# Altium schematic sheet lv_signal_link.SchDoc(lv_signal_link)
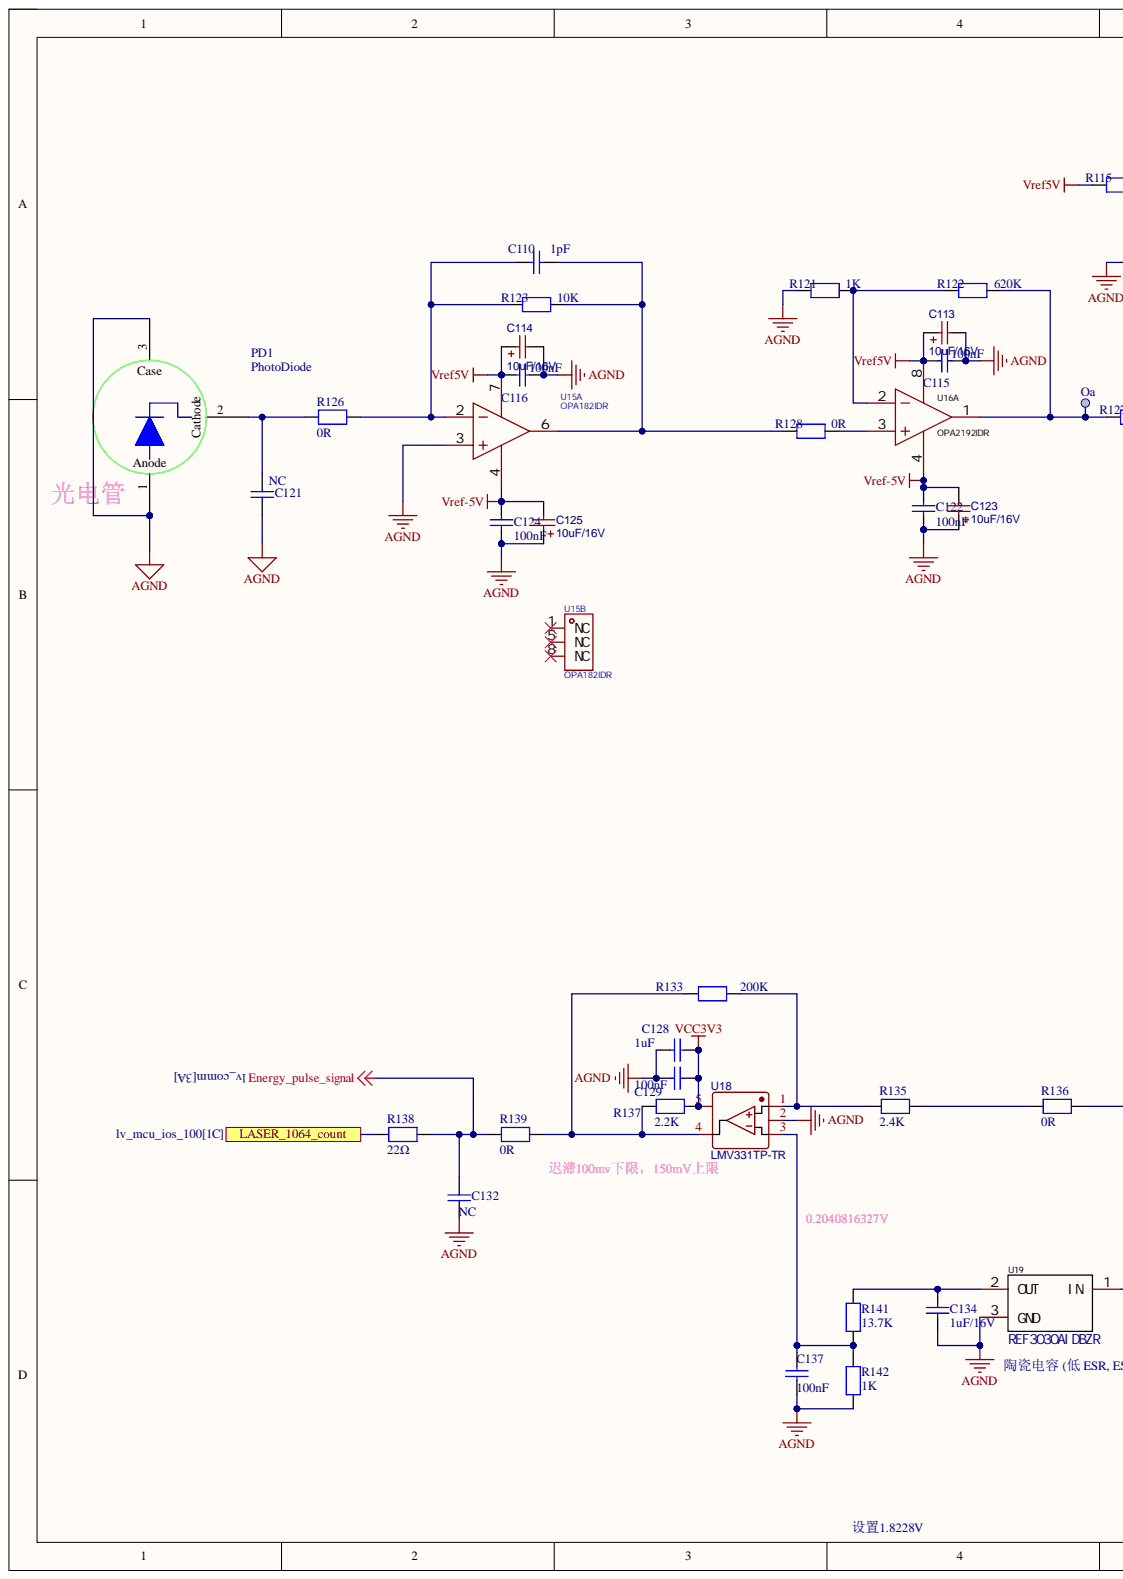
[diagram p0: lv_signal_link.SchDoc, left]
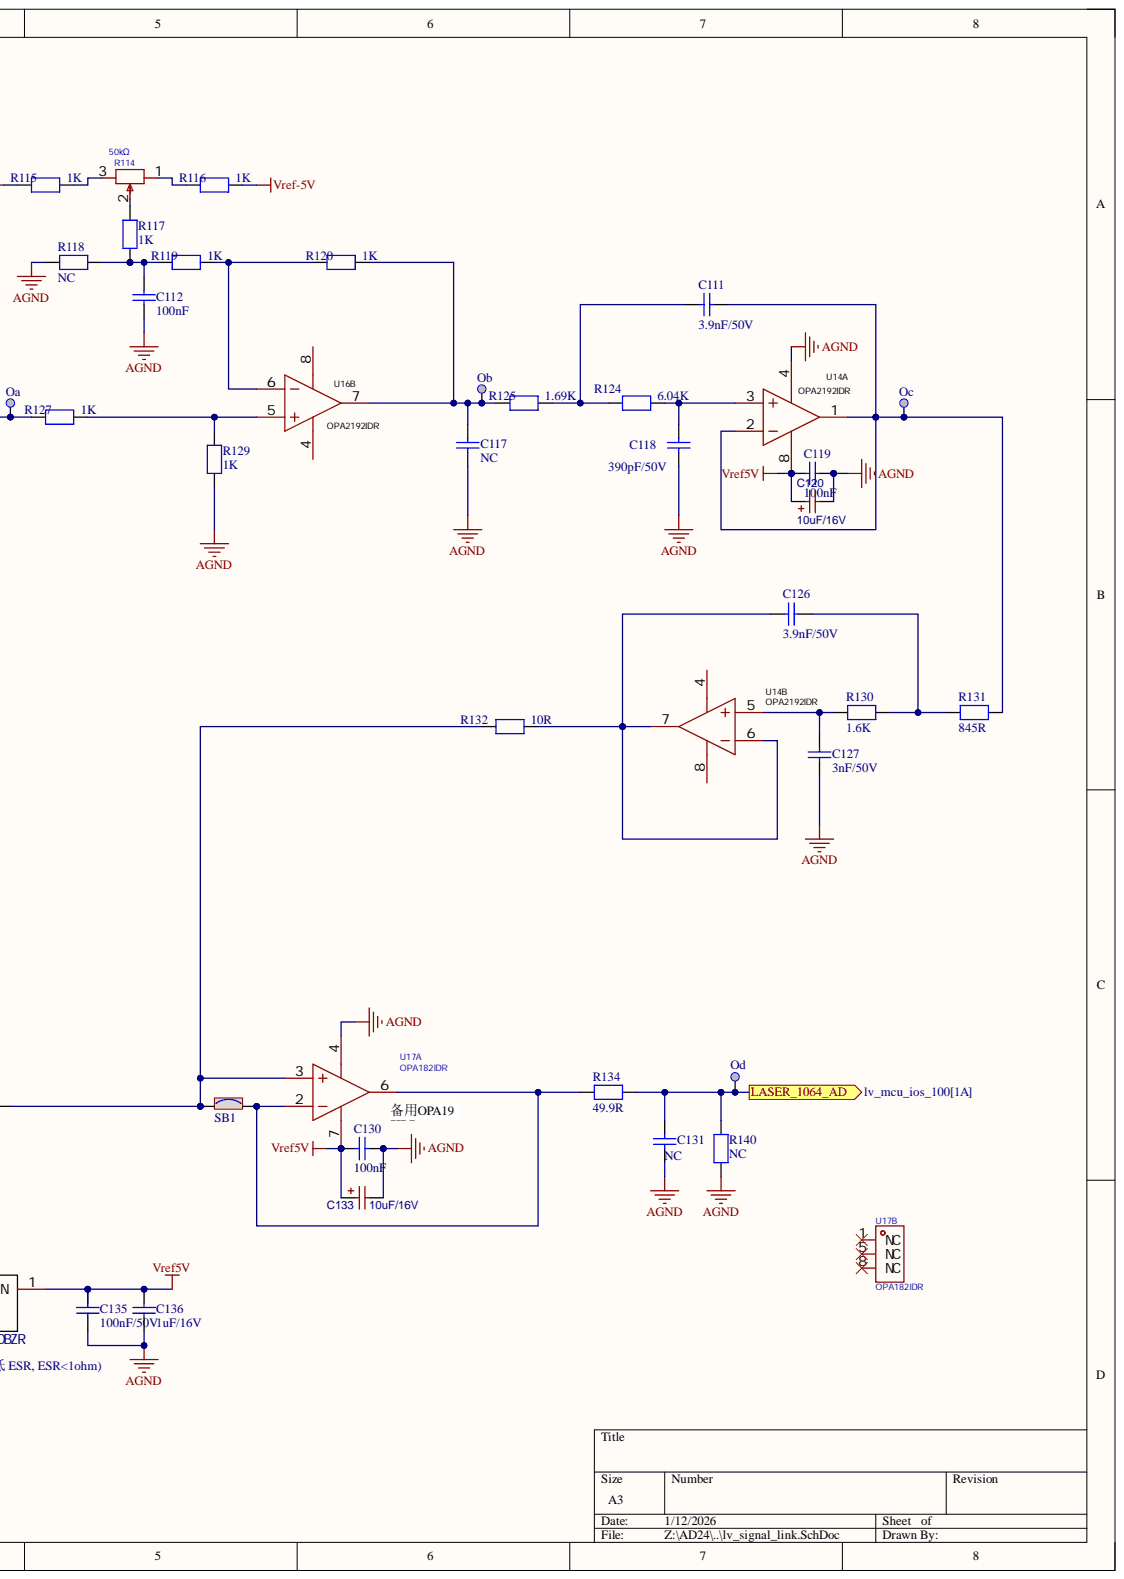
[diagram p1: lv_signal_link.SchDoc, right]

## Parts
C110  1pF  [C0603]
C111  3.9nF/50V  [C0603]
C112  100nF  [C0402]
C113  10uF/16V  [CAP-SMD_L3.2-W1.6-RD-C7171]
C114  10uF/16V  [CAP-SMD_L3.2-W1.6-RD-C7171]
C115  100nF  [C0402]
C116  100nF  [C0402]
C117  NC  [C0603]
C118  390pF/50V  [C0603]
C119  100nF  [C0402]
C120  10uF/16V  [CAP-SMD_L3.2-W1.6-RD-C7171]
C121  NC  [C0402]
C122  100nF  [C0402]
C123  10uF/16V  [CAP-SMD_L3.2-W1.6-RD-C7171]
C124  100nF  [C0402]
C125  10uF/16V  [CAP-SMD_L3.2-W1.6-RD-C7171]
C126  3.9nF/50V  [C0603]
C127  3nF/50V  [C0603]
C128  1uF  [C0603]
C129  100nF  [C0402]
C130  100nF  [C0402]
C131  NC  [C0603]
C132  NC  [C0603]
C133  10uF/16V  [CAP-SMD_L3.2-W1.6-RD-C7171]
C134  1uF/16V  [C0603]
C135  100nF/50V  [C0402]
C136  1uF/16V  [C0603]
C137  100nF  [C0402]
PD1  PhotoDiode  [photodiode1064]
R114  50kΩ  [RES-ADJ-SMD_3224W]
R115  1K  [R0603]
R116  1K  [R0603]
R117  1K  [R0603]
R118  NC  [R0603]
R119  1K  [R0603]
R120  1K  [R0603]
R121  1K  [R0603]
R122  620K  [R0603]
R123  10K  [R0603]
R124  6.04K  [R0603]
R125  1.69K  [R0603]
R126  0R  [R0402]
R127  1K  [R0603]
R128  0R  [R0603]
R129  1K  [R0603]
R130  1.6K  [R0603]
R131  845R  [R0603]
R132  10R  [R0603]
R133  200K  [R0402]
R134  49.9R  [R0402]
R135  2.4K  [R0402]
R136  0R  [R0603]
R137  2.2K  [R0402]
R138  22Ω  [R0402]
R139  0R  [R0402]
R140  NC  [R0402]
R141  13.7K  [R0402]
R142  1K  [R0402]
SB1  SB_ON  [SOLDER-BRIDGE_R0603-0R]  (value not drawn)
TP17  Ob  [TP_SMD_1MM]  (no BOM)
TP18  Oa  [TP_SMD_1MM]  (no BOM)
TP19  Oc  [TP_SMD_1MM]  (no BOM)
TP20  Od  [TP_SMD_1MM]  (no BOM)
U14  OPA2192IDR  [SOIC-8_L4.9-W3.9-P1.27-LS6.0-BL]  (parts A,B)
U15  OPA182IDR  [SOIC-8_L4.9-W3.9-P1.27-LS6.0-BL]  (parts A,B)
U16  OPA2192IDR  [SOIC-8_L4.9-W3.9-P1.27-LS6.0-BL]  (parts A,B)
U17  OPA182IDR  [SOIC-8_L4.9-W3.9-P1.27-LS6.0-BL]  (parts A,B)
U18  LMV331TP-TR  [SOT-23-5_L3.0-W1.7-P0.95-LS2.8-BR]
U19  REF3030AIDBZR  [SOT-23-3_L2.9-W1.6-P1.90-LS2.8-BR]

## Ports
LASER_1064_AD, LASER_1064_count

## Nets
AGND: C112.2 C113.2 C114.2 C115.2 C116.2 C117.1 C118.1 C119.2 C120.2 C121.2 C122.2 C123.1 C124.2 C125.1 C127.1 C128.1 C129.2 C130.2 C131.1 C132.1 C133.2 C134.1 C135.1 C136.1 C137.2 PD1.1 PD1.3 R118.1 R121.2 R129.2 R140.1 R142.1 U14.4 U15.3 U17.4 U18.2 U19.3
Energy_pulse_signal: C132.2 R138.2 R139.1
VCC3V3: C128.2 C129.1 R137.2 U18.5
Vref5V: C113.1 C114.1 C115.1 C116.1 C119.1 C120.1 C130.1 C133.1 C135.2 C136.2 R115.2 U14.8 U15.7 U16.8 U17.7 U19.1
Vref-5V: C122.1 C123.2 C124.1 C125.2 R116.1 U15.4 U16.4
LASER_1064_AD: C131.2 R134.2 R140.2 TP20.1 ; port LASER_1064_AD
LASER_1064_count: R138.1 ; port LASER_1064_count
NetC110_1: C110.1 R123.2 R126.2 U15.2
NetC110_2: C110.2 R123.1 R128.2 U15.6
NetC111_1: C111.1 R131.2 TP19.1 U14.1 U14.2
NetC111_2: C111.2 R124.2 R125.1
NetC112_1: C112.1 R117.2 R118.2 R119.2
NetC117_2: C117.2 R120.1 R125.2 TP17.1 U16.7
NetC118_2: C118.2 R124.1 U14.3
NetC121_1: C121.1 PD1.2 R126.1
NetC126_1: C126.1 R132.1 U14.6 U14.7
NetC126_2: C126.2 R130.2 R131.1
NetC127_2: C127.2 R130.1 U14.5
NetC134_2: C134.2 R141.2 U19.2
NetC137_1: C137.1 R141.1 R142.2 U18.3
NetR114_1: R114.1 R116.2
NetR114_2: R114.2 R117.1
NetR114_3: R114.3 R115.1
NetR119_1: R119.1 R120.2 U16.6
NetR121_1: R121.1 R122.2 U16.2
NetR122_1: R122.1 R127.2 TP18.1 U16.1
NetR127_1: R127.1 R129.1 U16.5
NetR128_1: R128.1 U16.3
NetR132_2: R132.2 R136.2 SB1.1 U17.3
NetR133_1: R133.1 R135.2 U18.1
NetR133_2: R133.2 R137.1 R139.2 U18.4
NetR134_1: R134.1 SB1.2 U17.2 U17.6
NetR135_1: R135.1 R136.1

Not connected: U15 1(NC) 5(NC) 8(NC); U17 1(NC) 5(NC) 8(NC)

## Library data of the parts
C110 = Capacitor_1: 16V, X5R, 10%; Internal_Part_Number: MCD-00009; Manufacturer: YAGEO; Manufacturer_Part_Number: CC0603BRNPO9BN1R0; Component Kind: Standard; ComponentLink1Description: Yageo_Capacitor_X5R-series.pdf; ComponentLink1URL: http://tunmcdsvn.tun.st.com/Component_Datasheet_Library/Trunk/Capacitors/Yageo_Multilayer_capacitor_X5R_series_100pF_to_100uF; Library Name: HiPin_Library_AD.IntLib; Package: C0603
C111 = Capacitor_1: 50V, COG, 10%; Internal_Part_Number: MCD-00009; Manufacturer: muRata; Manufacturer_Part_Number: GRM1885C1H392JA01D; Component Kind: Standard; ComponentLink1Description: Yageo_Capacitor_X5R-series.pdf; ComponentLink1URL: http://tunmcdsvn.tun.st.com/Component_Datasheet_Library/Trunk/Capacitors/Yageo_Multilayer_capacitor_X5R_series_100pF_to_100uF; Library Name: HiPin_Library_AD.IntLib; Package: C0603
C112 C115 C116 C119 C122 C124 C129 C130 C137 = Capacitor: 50V, 10%, X7R; Internal_Part_Number: MCD-00003; Manufacturer: muRata; Manufacturer_Part_Number: GRM155R71H104KE14D; Component Kind: Standard; ComponentLink1Description: Datasheet; ComponentLink1URL: http://tunmcdsvn.tun.st.com/Component_Datasheet_Library/Trunk/Capacitors/Yageo_Capacitor_NP0_16V-to-50V; Library Name: HiPin_Library_AD.IntLib; Package: C0402; Value: 100nF
C113 C114 C120 C123 C125 C133 = 10uF: TA 0.8ohm,10%; Manufacturer: AVX; Manufacturer_Part_Number: TAJA106K016RNJ; Component Kind: Standard; Library Name: HiPin_Library_AD.IntLib
C117 = Capacitor_1: 16V, X5R, 10%; Internal_Part_Number: MCD-00009; Manufacturer: muRata; Manufacturer_Part_Number: GRM21BR61C106KE15L; Component Kind: Standard; ComponentLink1Description: Yageo_Capacitor_X5R-series.pdf; ComponentLink1URL: http://tunmcdsvn.tun.st.com/Component_Datasheet_Library/Trunk/Capacitors/Yageo_Multilayer_capacitor_X5R_series_100pF_to_100uF; Library Name: HiPin_Library_AD.IntLib; Package: C0603
C118 = Capacitor_1: 50V, C0G, 5%; Manufacturer: muRata; Manufacturer_Part_Number: GRM1885C1H391JA01D; Component Kind: Standard; Library Name: HiPin_Library_AD.IntLib; Package: C0603
C121 = Capacitor: 50V, 10%, X7R; Internal_Part_Number: MCD-00003; Manufacturer: muRata; Component Kind: Standard; ComponentLink1Description: Datasheet; ComponentLink1URL: http://tunmcdsvn.tun.st.com/Component_Datasheet_Library/Trunk/Capacitors/Yageo_Capacitor_NP0_16V-to-50V; Library Name: HiPin_Library_AD.IntLib; Package: C0402
C126 = Capacitor_1: 50V, C0G, 5%; Manufacturer: muRata; Manufacturer_Part_Number: GRM1885C1H392JA01D; Component Kind: Standard; Library Name: HiPin_Library_AD.IntLib
C127 = Capacitor_1: 50V,C0G, 5%; Manufacturer: muRata; Manufacturer_Part_Number: GRM1885C1H302JA01D; Component Kind: Standard; Library Name: HiPin_Library_AD.IntLib; Package: C0603
C128 = MCD-00010: Capacitor, MLCC, 1uF, 16V, X5R, 10%, 0603, T=0,8mm; Manufacturer: YAGEO; Manufacturer_Part_Number: CC0603KRX5R7BB105; Database Table Name: MCD_PARTS$; Initial_Board_Reference: MB1274; Provided_By: Subcon; Component Kind: Standard; ComponentLink1Description: Yageo_Capacitor_X5R-series.pdf; ComponentLink1URL: http://tunmcdsvn.tun.st.com/Component_Datasheet_Library/Trunk/Capacitors/Yageo_Multilayer_capacitor_X5R_series_100pF_to_100uF; ECCN_EU: n/a; ECCN_US: n/a; Internal_Part_Number: MCD-00010; Library Name: MCD_PARTS_database.DbLib; Package: C0603; Part_Type: Capacitor; Status: Released
C131 C132 = Capacitor_1: 50V, X7R, 10%; Manufacturer: YAGEO; Manufacturer_Part_Number: CC0603KRX7R9BB474; Component Kind: Standard; Library Name: HiPin_Library_AD.IntLib
C134 C136 = MCD-00010: X5R, 10%; Manufacturer: YAGEO; Manufacturer_Part_Number: CC0603KRX5R7BB105; Component Kind: Standard; Library Name: MB1397.IntLib
  C134: ComponentLink1Description: Datasheet; ComponentLink1URL: http://tunmcdsvn.tun.st.com/Component_Datasheet_Library/Trunk/Capacitors/Yageo_Multilayer_capacitor_X5R_series_100pF_to_100uF
C135 = MCD-00006: X7R, 10%; Alternate_Manufacturer: MURATA; Alternate_Manufacturer_Part_Number: GCM155R71C104KA55D; Manufacturer_Part_Number: GRM155R71H104KE14D; Initial_Board_Reference: MB1274; Item Type: Item; Path: ws/MCD_ApplicationBoards/Lists/MCDPARTSdatabase; Component Kind: Standard; ComponentLink1Description: Datasheet; ComponentLink1URL: http://tunmcdsvn.tun.st.com/Component_Datasheet_Library/Trunk/Capacitors/Yageo_Multilayer_Capacitor_X7R_series_100pF_to_22uF; Library Name: MB1397.IntLib; Manufacturer: MURATA
R114 = 3224W-1-103E: 可调电阻; Manufacturer: BOURNS; Manufacturer Part: 3224W-1-503E; Temperature Coefficient: ±100ppm/℃; Component Kind: Standard; Datasheet: https://atta.szlcsc.com/upload/public/pdf/source/20160830/1472551532733.pdf; Library Name: HiPin_Library_AD.IntLib; PCB3D: RES-ADJ-SMD_3224W
R115-R125 R127-R132 R134 R136 R138 = RES: 1%; Component Kind: Standard; Library Name: HiPin_Library_AD.IntLib
R126 R133 R135 R137 R139 R140 = RES: 1%; Value: 10K; Component Kind: Standard; Library Name: HiPin_Library_AD.IntLib
R141 R142 = RES: Resistor; Component Kind: Standard; Library Name: HiPin_Library_AD.IntLib
SB1 = SB_ON: Solder Bridge ON; Internal_Part_Number: MCD-00071; Manufacturer: YAGEO; Manufacturer_Part_Number: RC0603JR-070RL; Part_Type: Mechanical; Provided_By: SUBCO; Component Kind: Standard; ComponentLink1Description: Datasheet; ComponentLink1URL: http://tunmcdsvn.tun.st.com/Component_Datasheet_Library/Trunk/Resistors/Yageo_Resistor_RC0603-series; ECCN_EU: n/a; ECCN_US: n/a; Library Name: HiPin_Library_AD.IntLib; Package: SB0603; Status: Released
TP17-TP20 = MCD-00074: Test Point 1mm; Part_Type: Mechanical; Database Table Name: MCD_PARTS$; ComponentLink1Description: Datasheet; ComponentLink1URL: http://na; ECCN_EU: n/a; ECCN_US: n/a; Internal_Part_Number: MCD-00074; Library Name: MCD_PARTS_database.DbLib; Manufacturer: n/a; Manufacturer_Part_Number: n/a; Provided_By: n/a; Status: Released
U14 U16 = OPA2170AIDR: Manufacturer: TI(德州仪器); Manufacturer Part: OPA2350UA/2K5; Component Kind: Standard; Library Name: HiPin_Library_AD.IntLib
U15 = OPA182IDR: FET输入运放，但路，145Mhz， 1pA; Manufacturer: ADI; Manufacturer Part: AD8065ARZ; Gain Bandwidth Product(GBP): 145MHz; Number of Circuits: 单路; Component Kind: Standard; Library Name: HiPin_Library_AD.IntLib; Operating Temperature: -40℃~+85℃; PCB3D: SOIC-8_L4.9-W3.9-H1.7-LS6.0-P1.27; Supplier Footprint: SOIC-8
U17 = OPA182IDR: 36V、5MHz、低噪声、零漂移、多路复用器友好型精密运算放大器; Manufacturer: TI(德州仪器); -3db Bandwidth(G=1): 3.6MHz; Add into BOM: yes; Current - Input Bias(Ib): 50pA; Gain Bandwidth Product(GBP): 5MHz; Number of Circuits: 单路; Slew Rate(SR): 10V/us; Voltage - Input Offset(Vos): 450nV; Component Kind: Standard; Convert to PCB: yes; Datasheet: https://www.ti.com/cn/lit/gpn/opa2182; Device: OPA182IDR; JLCPCB Part Class: Extended Part; LCSC Part Name: OPA182IDR; Library Name: HiPin_Library_AD.IntLib; Manufacturer Part: OPA182IDR; Operating Temperature: -40℃~+125℃; Output Type: -; PCB3D: SOIC-8_L4.9-W3.9-H1.7-LS6.0-P1.27; Rail to Rail: -; Supplier: LCSC; Supplier Footprint: SOIC-8; Supplier Part: C4371168; Symbol: OPA182IDR
U18 = LMV331TP-TR: 比较器; BOM_Manufacturer: 3PEAK; Contributor: LCSC; BOM_JLCPCB Part Class: Extended Part; BOM_Manufacturer Part: LMV331TP-TR; BOM_Supplier: LCSC; BOM_Supplier Part: C108095; Component Kind: Standard; Library Name: HiPin_Library_AD.IntLib; spicePre: U; spiceSymbolName: LMV331TP-TR
U19 = REF3030AIDBZR: Manufacturer: TI(德州仪器); Add into BOM: yes; Component Kind: Standard; Convert to PCB: yes; Datasheet: https://atta.szlcsc.com/upload/public/pdf/source/20141125/1457707248562.pdf; Device: REF3030AIDBZR; JLCPCB Part Class: Extended Part; LCSC Part Name: REF3030AIDBZR; Library Name: HiPin_Library_AD.IntLib; Manufacturer Part: REF3030AIDBZR; Name: REF3030AIDBZR; PCB3D: SOT-23-3L_L2.9-W1.6-H1.1-LS2.8-P0.95; Supplier: LCSC; Supplier Footprint: SOT-23; Supplier Part: C38423; Symbol: REF3030AIDBZR
PD1 = PhotoDiode: Component Kind: Standard; Library Name: HiPin_Library_AD.IntLib
